# Altium schematic sheet top_sch.SchDoc(top_sch)
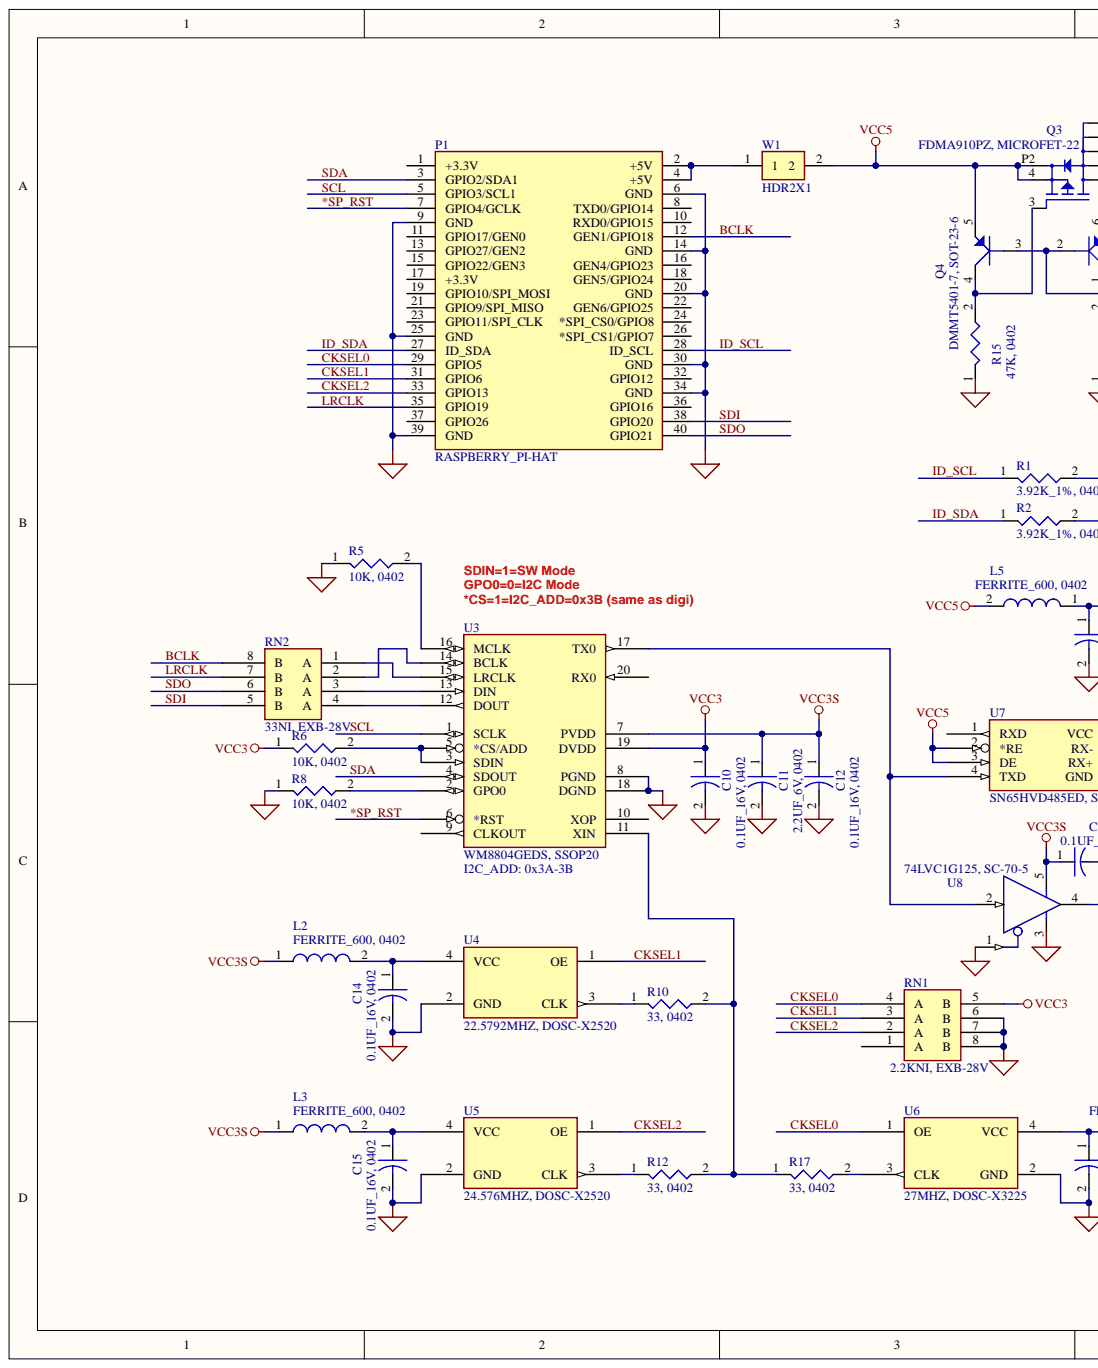
[diagram p0: top_sch.SchDoc, left]
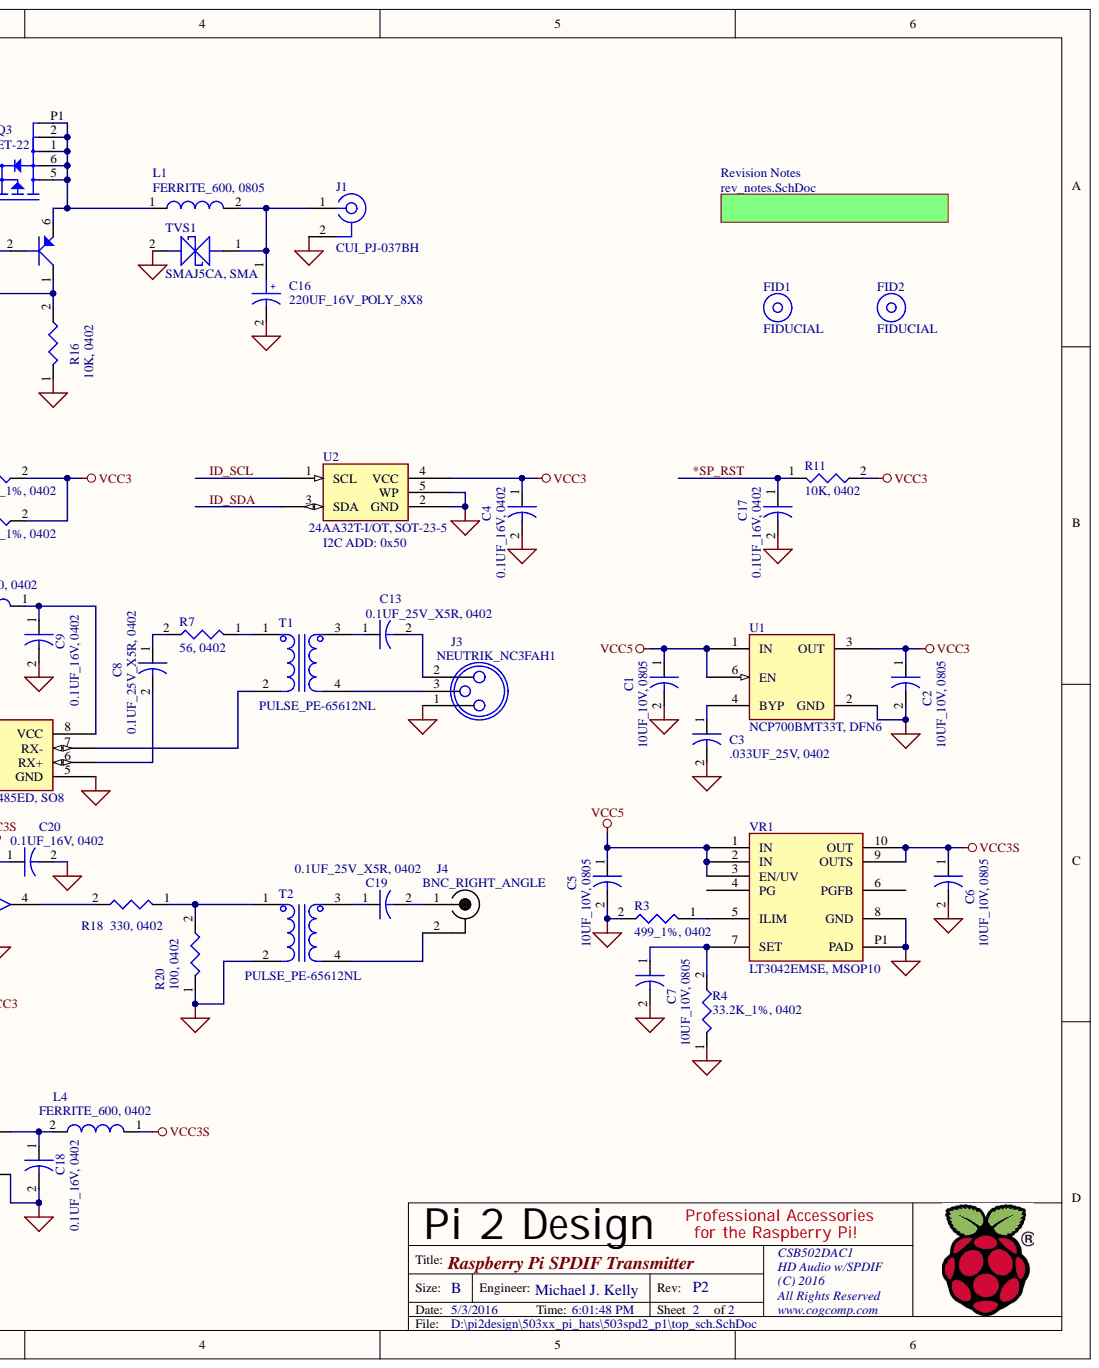
[diagram p1: top_sch.SchDoc, right]

## Parts
C1  10UF_10V, 0805  [0805C]
C2  10UF_10V, 0805  [0805C]
C3  .033UF_25V, 0402  [0402C]
C4  0.1UF_16V, 0402  [0402C]
C5  10UF_10V, 0805  [0805C]
C6  10UF_10V, 0805  [0805C]
C7  10UF_10V, 0805  [0805C]
C8  0.1UF_25V_X5R, 0402  [0402C]
C9  0.1UF_16V, 0402  [0402C]
C10  0.1UF_16V, 0402  [0402C]
C11  2.2UF_6V, 0402  [0402C]
C12  0.1UF_16V, 0402  [0402C]
C13  0.1UF_25V_X5R, 0402  [0402C]
C14  0.1UF_16V, 0402  [0402C]
C15  0.1UF_16V, 0402  [0402C]
C16  220UF_16V_POLY_8X8  [ELEC_8MM_8MM]
C17  0.1UF_16V, 0402  [0402C]
C18  0.1UF_16V, 0402  [0402C]
C19  0.1UF_25V_X5R, 0402  [0402C]
C20  0.1UF_16V, 0402  [0402C]
FID1  FIDUCIAL  [FIDUCIAL]
FID2  FIDUCIAL  [FIDUCIAL]
J1  CUI_PJ-037BH  [CUI_PJ-037B]
J3  NEUTRIK_NC3FAH1  [NEUTRIK_NC3FAH1-0]
J4  BNC_RIGHT_ANGLE  [BNC_RIGHT_ANGLE]
L1  FERRITE_600, 0805  [0805L]
L2  FERRITE_600, 0402  [0402L]
L3  FERRITE_600, 0402  [0402L]
L4  FERRITE_600, 0402  [0402L]
L5  FERRITE_600, 0402  [0402L]
P1  RASPBERRY_PI-HAT  [RASPBERRY_PI-HAT]
Q3  FDMA910PZ, MICROFET-22  [MICROFET-22]
Q4  DMMT5401-7, SOT-23-6  [SOT-23-6]
R1  3.92K_1%, 0402  [0402R]
R2  3.92K_1%, 0402  [0402R]
R3  499_1%, 0402  [0402R]
R4  33.2K_1%, 0402  [0402R]
R5  10K, 0402  [0402R]
R6  10K, 0402  [0402R]
R7  56, 0402  [0402R]
R8  10K, 0402  [0402R]
R10  33, 0402  [0402R]
R11  10K, 0402  [0402R]
R12  33, 0402  [0402R]
R15  47K, 0402  [0402R]
R16  10K, 0402  [0402R]
R17  33, 0402  [0402R]
R18  330, 0402  [0402R]
R20  100, 0402  [0402R]
RN1  2.2KNI, EXB-28V  [EXB-28V]
RN2  33NI, EXB-28V  [EXB-28V]
T1  PULSE_PE-65612NL  [PULSE_PE-65612]
T2  PULSE_PE-65612NL  [PULSE_PE-65612]
TVS1  SMAJ5CA, SMA  [SMA]
U1  NCP700BMT33T, DFN6  [DFN6-1.5MM]
U2  24AA32T-I/OT, SOT-23-5  I2C ADD: 0x50  [SOT-23-5]
U3  WM8804GEDS, SSOP20  I2C_ADD: 0x3A-3B  [SSOP20]
U4  22.5792MHZ, DOSC-X2520  [X2520]
U5  24.576MHZ, DOSC-X2520  [X2520]
U6  27MHZ, DOSC-X3225  [X3225]
U7  SN65HVD485ED, SO8  [SO8]
U8  74LVC1G125, SC-70-5  [SOT-353/SC-70-5]
VR1  LT3042EMSE, MSOP10  [MSOP10P]
W1  HDR2X1  [HDR2X1]

## Nets
GND: C1.2 C2.2 C3.2 C4.2 C5.2 C6.2 C7.2 C9.2 C10.2 C11.2 C12.2 C14.2 C15.2 C16.2 C17.2 C18.2 C20.2 J1.2 J3.1 P1.6(GND) P1.9(GND) P1.14(GND) P1.20(GND) P1.25(GND) P1.30(GND) P1.34(GND) P1.39(GND) R3.2 R4.1 R5.1 R8.1 R15.1 R16.1 R20.1 RN1.6(B) RN1.7(B) RN1.8(B) T2.2 TVS1.2 U1.2(GND) U2.2(GND) U2.5(WP) U3.8(PGND) U3.18(DGND) U4.2(GND) U5.2(GND) U6.2(GND) U7.5(GND) U8.1 U8.3 VR1.8(GND) VR1.P1(PAD)
VCC3: C2.1 C4.1 C10.1 R1.2 R2.2 R6.1 R11.2 RN1.5(B) U1.3(OUT) U2.4(VCC) U3.19(DVDD)
VCC3S: C6.1 C11.1 C12.1 C20.1 L2.1 L3.1 L4.1 U3.7(PVDD) U8.5 VR1.9(OUTS) VR1.10(OUT)
VCC5: C1.1 C5.1 L5.2 Q3.4 Q3.P2 Q4.5 U1.1(IN) U1.6(EN) U7.2(*RE) U7.3(DE) VR1.1(IN) VR1.2(IN) VR1.3(EN/UV) W1.2
*SP_RST_2: C17.1 P1.7(GPIO4/GCLK) R11.1 U3.6(*RST)  [also labelled *SP_RST]
BCLK_2: P1.12(GEN1/GPIO18) RN2.8(B)  [also labelled BCLK]
CKSEL0_2: P1.29(GPIO5) RN1.4(A) U6.1(OE)  [also labelled CKSEL0]
CKSEL1_2: P1.31(GPIO6) RN1.3(A) U4.1(OE)  [also labelled CKSEL1]
CKSEL2_2: P1.33(GPIO13) RN1.2(A) U5.1(OE)  [also labelled CKSEL2]
ID_SCL_2: P1.28(ID_SCL) R1.1 U2.1(SCL)  [also labelled ID_SCL]
ID_SDA_2: P1.27(ID_SDA) R2.1 U2.3(SDA)  [also labelled ID_SDA]
LRCLK_2: P1.35(GPIO19) RN2.7(B)  [also labelled LRCLK]
SCL_2: P1.5(GPIO3/SCL1) U3.1(SCLK)  [also labelled SCL]
SDA_2: P1.3(GPIO2/SDA1) U3.4(SDOUT)  [also labelled SDA]
SDI_2: P1.38(GPIO20) RN2.5(B)  [also labelled SDI]
SDO_2: P1.40(GPIO21) RN2.6(B)  [also labelled SDO]
NetC3_1: C3.1 U1.4(BYP)
NetC7_1: C7.1 R4.2 VR1.7(SET)
NetC8_1: C8.1 R7.2
NetC8_2: C8.2 U7.6(RX+)
NetC9_1: C9.1 L5.1 U7.8(VCC)
NetC13_1: C13.1 T1.3
NetC13_2: C13.2 J3.2
NetC14_1: C14.1 L2.2 U4.4(VCC)
NetC15_1: C15.1 L3.2 U5.4(VCC)
NetC16_1: C16.1 J1.1 L1.2 TVS1.1
NetC18_1: C18.1 L4.2 U6.4(VCC)
NetC19_1: C19.1 T2.3
NetC19_2: C19.2 J4.1
NetJ3_3: J3.3 T1.4
NetJ4_2: J4.2 T2.4
NetL1_1: L1.1 Q3.1 Q3.2 Q3.5 Q3.6 Q3.P1 Q4.6
NetP1_2: P1.2(+5V) P1.4(+5V) W1.1
NetQ3_3: Q3.3 Q4.4 R15.2
NetQ4_1: Q4.1 Q4.2 Q4.3 R16.2
NetR3_1: R3.1 VR1.5(ILIM)
NetR5_2: R5.2 U3.16(MCLK)
NetR6_2: R6.2 U3.3(SDIN) U3.5(*CS/ADD)
NetR7_1: R7.1 T1.1
NetR8_2: R8.2 U3.2(GPO0)
NetR10_1: R10.1 U4.3(CLK)
NetR10_2: R10.2 R12.2 R17.1 U3.11(XIN)
NetR12_1: R12.1 U5.3(CLK)
NetR17_2: R17.2 U6.3(CLK)
NetR18_1: R18.1 R20.2 T2.1
NetR18_2: R18.2 U8.4
NetRN2_1: RN2.1(A) U3.15(LRCLK)
NetRN2_2: RN2.2(A) U3.14(BCLK)
NetRN2_3: RN2.3(A) U3.13(DIN)
NetRN2_4: RN2.4(A) U3.12(DOUT)
NetT1_2: T1.2 U7.7(RX-)
NetU3_17: U3.17(TX0) U7.4(TXD) U8.2

Not connected: P1 1(+3.3V) 8(TXD0/GPIO14) 10(RXD0/GPIO15) 11(GPIO17/GEN0) 13(GPIO27/GEN2) 15(GPIO22/GEN3) 16(GEN4/GPIO23) 17(+3.3V) 18(GEN5/GPIO24) 19(GPIO10/SPI_MOSI) 21(GPIO9/SPI_MISO) 22(GEN6/GPIO25) 23(GPIO11/SPI_CLK) 24(*SPI_CS0/GPIO8) 26(*SPI_CS1/GPIO7) 32(GPIO12) 36(GPIO16) 37(GPIO26); RN1 1(A); U3 9(CLKOUT) 10(XOP) 20(RX0); U7 1(RXD); VR1 4(PG) 6(PGFB)

## Library data of the parts
C1 C2 C5-C7 = 10UF_10V, 0805: CAP - 0805 PKG; Component Kind: Standard; Library Name: COGENT_Library.SchLib
C3 = .033UF_25V, 0402: CAP - 0402 PKG; Component Kind: Standard; Library Name: COGENT_Library.SchLib
C4 C9 C10 C12 C14 C15 C17 C18 C20 = 0.1UF_16V, 0402: CAP - 0402 PKG; Component Kind: Standard; Library Name: COGENT_Library.SchLib
C8 C13 C19 = 0.1UF_25V_X5R, 0402: CAP - 0402 PKG; Component Kind: Standard; Library Name: COGENT_Library.SchLib
C11 = 2.2UF_6V, 0402: CAP - 0402 PKG; Component Kind: Standard; Library Name: COGENT_Library.SchLib
C16 = 220UF_16V_POLY_8X8: CAP - ELEC_POLY, 8mm x 8mm x 3.5mm; Component Kind: Standard; Library Name: COGENT_Library.SchLib
FID1 FID2 = FIDUCIAL: MISC - 75mm/35mm FIDUCIAL; Component Kind: Standard; Library Name: COGENT_Library.SchLib
J1 = CUI_PJ-037BH: CONN - DC JACK, 2.5mm CENTER PLUS, 5 AMP; Component Kind: Standard; Library Name: COGENT_Library.SchLib
J3 = NEUTRIK_NC3FAH1: CONN - XLR FEMALE, 3-PIN, RIGHT ANGLE; Component Kind: Standard; Library Name: COGENT_Library.SchLib
J4 = BNC_RIGHT_ANGLE: CONN - BNC 75 OHM, RIGHT ANGLE; Component Kind: Standard; Library Name: COGENT_Library.SchLib
L1 = FERRITE_600, 0805: FERRITE BEAD - 600 OHM, 2A, 0805 PKG; Component Kind: Standard; Library Name: COGENT_Library.SchLib
L2-L5 = FERRITE_600, 0402: FERRITE BEAD - 600 OHM, 300MA, 0402 PKG; Component Kind: Standard; Library Name: COGENT_Library.SchLib
P1 = RASPBERRY_PI-HAT: MODULE - RASPBERRY PI HAT, FOOTPRINT ONLY; Component Kind: Standard; Library Name: COGENT_Library.SchLib
Q3 = FDMA910PZ, MICROFET-22: P-FET - 20V, 7.2A @ 1.8Vgs, 34mohm; Component Kind: Standard; Library Name: COGENT_Library.SchLib
Q4 = DMMT5401-7, SOT-23-6: PNP - MATCHED PAIR, 150V, 200MA, GAIN = 100; Component Kind: Standard; Library Name: COGENT_Library.SchLib
R1 R2 = 3.92K_1%, 0402: RES - 0402 PKG; Component Kind: Standard; Library Name: COGENT_Library.SchLib
R3 = 499_1%, 0402: RES - 0402 PKG; Component Kind: Standard; Library Name: COGENT_Library.SchLib
R4 = 33.2K_1%, 0402: RES - 0402 PKG; Component Kind: Standard; Library Name: COGENT_Library.SchLib
R5 R6 R8 R11 = 10K, 0402: RES - 0402 PKG; Component Kind: Standard; Library Name: COGENT_Library.SchLib
R7 = 56, 0402: RES - 0402 PKG; Component Kind: Standard; Library Name: COGENT_Library.SchLib
R10 R12 R17 = 33, 0402: RES - 0402 PKG; Component Kind: Standard; Library Name: COGENT_Library.SchLib
R15 = 47K, 0402: RES - 0402 PKG; Component Kind: Standard; Library Name: COGENT_Library.SchLib
R16 = 1K, 0402: RES - 0402 PKG; Component Kind: Standard; Library Name: COGENT_Library.SchLib
R18 = 330, 0402: RES - 0402 PKG; Component Kind: Standard; Library Name: COGENT_Library.SchLib
R20 = 100, 0402: RES - 0402 PKG; Component Kind: Standard; Library Name: COGENT_Library.SchLib
RN1 = 2.2KNI, EXB-28V: RES NET, 4 INDIVIDUAL, EXB-28V; Component Kind: Standard; Library Name: COGENT_Library.SchLib
RN2 = 33NI, EXB-28V: RES NET, 4 INDIVIDUAL, EXB-28V; Component Kind: Standard; Library Name: COGENT_Library.SchLib
T1 T2 = PULSE_PE-65612NL: TRANSFORMER - 1:1, AUDIO AES3 AND S/PDIF; Component Kind: Standard; Library Name: COGENT_Library.SchLib
TVS1 = SMAJ5CA, SMA: DIODE - TVS, 6.4V MIN., 7.25V MAX.; Component Kind: Standard; Library Name: COGENT_Library.SchLib
U1 = NCP700BMT33T, DFN6: LDO - 0.5ua Iq, 150MA, 3.5V - 5.5V IN, 3.3V OUT, LOW NOISE, 82db; Component Kind: Standard; Library Name: COGENT_Library.SchLib
U2 = 24AA32T-I/OT, SOT-23-5: EEPROM - I2C, 4096X8, SOT-23-5 PKG; Component Kind: Standard; Library Name: COGENT_Library.SchLib
U3 = WM8804GEDS, SSOP20: AUDIO - I2S TO S/PDIF TRANSMITTER; Component Kind: Standard; Library Name: COGENT_Library.SchLib
U4 = 22.5792MHZ, DOSC-X2520: OSC - SMT, 2.5MM X 2.0MM; Component Kind: Standard; Library Name: COGENT_Library.SchLib
U5 = 24.576MHZ, DOSC-X2520: OSC - SMT, 2.5MM X 2.0MM; Component Kind: Standard; Library Name: COGENT_Library.SchLib
U6 = 27MHZ, DOSC-X3225: OSC - SMT, 3.2MM X 2.5MM; Component Kind: Standard; Library Name: COGENT_Library.SchLib
U7 = SN65HVD485ED, SO8: IC - RS485 TRANSCEIVER; Component Kind: Standard; Library Name: COGENT_Library.SchLib
U8 = 74LVC1G125, SC-70-5: GATE - TRI-STATE BUFFER, LOW TRUE EN; Component Kind: Standard; Library Name: COGENT_Library.SchLib
VR1 = LT3042EMSE, MSOP10: LDO - 2ma Iq, 200MA, 1.8V - 20V IN, 0V - 15V OUT, .9uv NOISE, 92db PSRR @ 10Khz; Component Kind: Standard; Library Name: COGENT_Library.SchLib
W1 = HDR2X1: HEADER - 2-PIN, SINGLE ROW; Component Kind: Standard; Library Name: COGENT_Library.SchLib
